# Altium schematic sheet WirelessLamp.SchDoc(WirelessLamp)
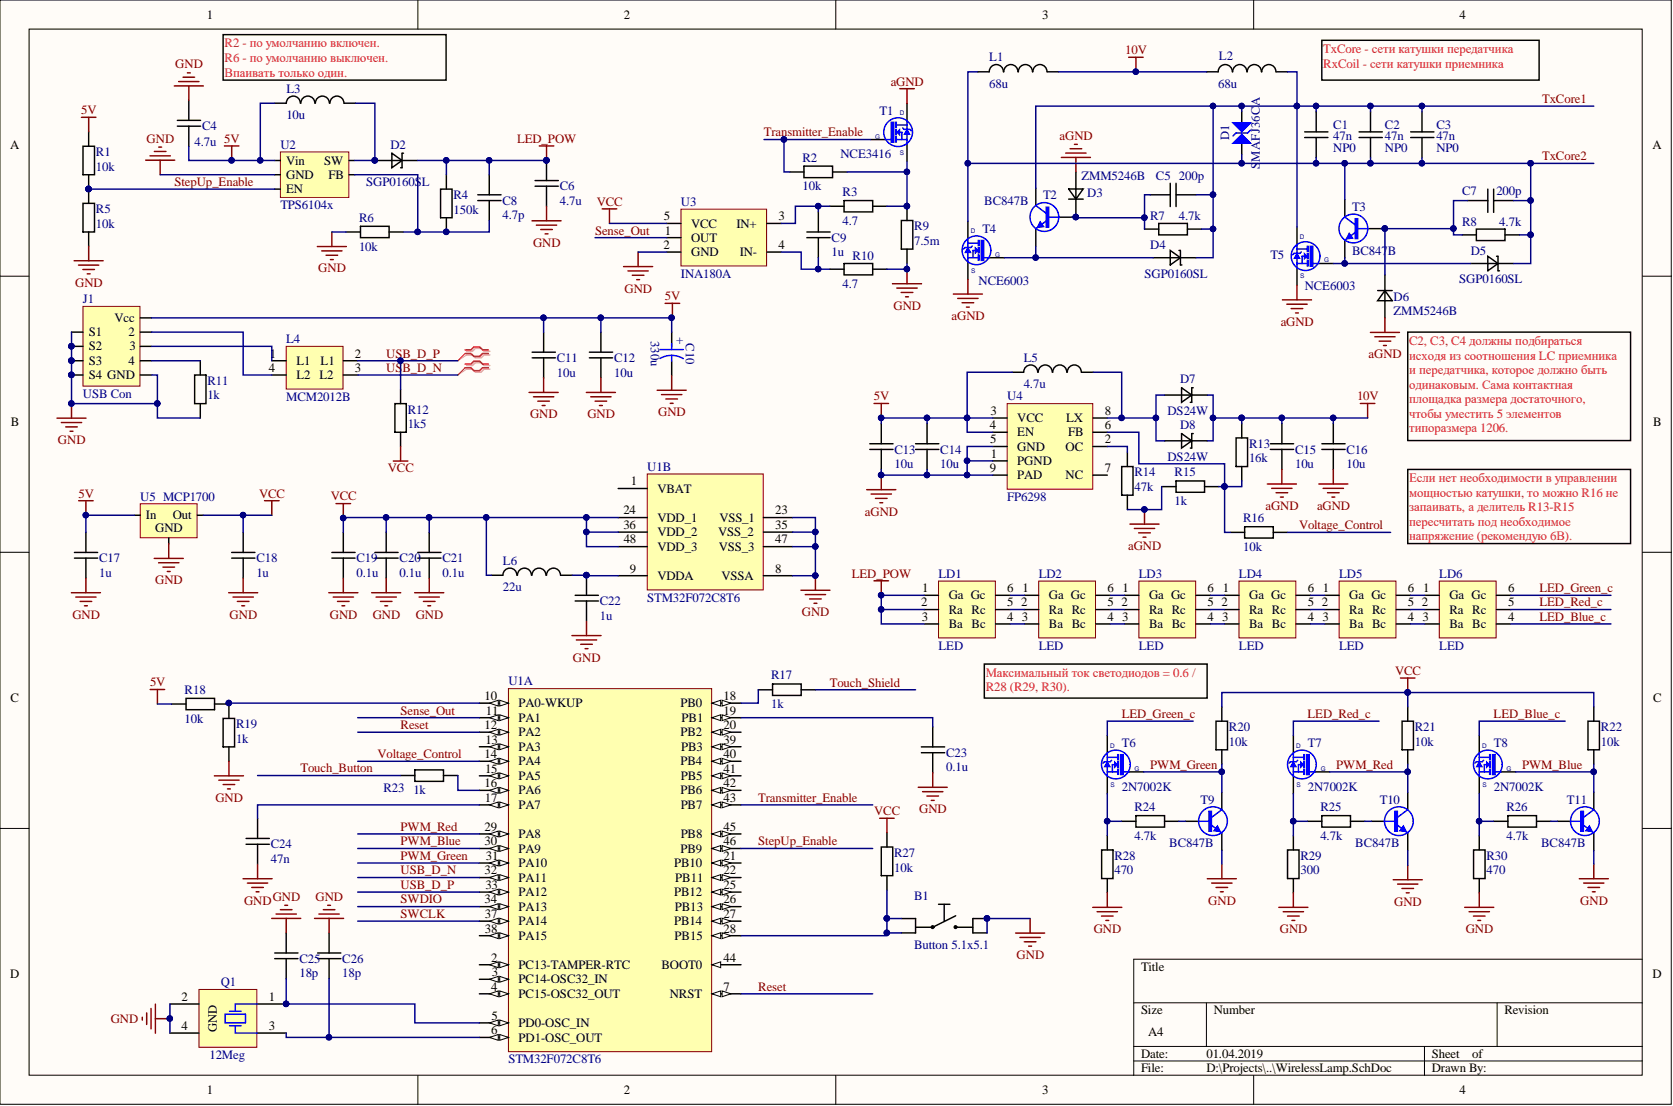
[diagram p0: WirelessLamp.SchDoc]

## Parts
B1  Button 5.1x5.1  [TS-1187 combo]
C1  47n  Name: NP0  [CAPC3225L]
C2  47n  Name: NP0  [CAPC3225L]
C3  47n  Name: NP0  [CAPC3225L]
C4  4.7u  [CAPC3216L]
C5  200p  [CAPC2012L]
C6  4.7u  [CAPC3216L]
C7  200p  [CAPC2012L]
C8  4.7p  [CAPC1608L]
C9  1u  [CAPC1608L]
C10  330u  [CAPPR250-680X1080]
C11  10u  [CAPC3216L]
C12  10u  [CAPC3216L]
C13  10u  [CAPC3216L]
C14  10u  [CAPC3216L]
C15  10u  [CAPC3225L]
C16  10u  [CAPC3225L]
C17  1u  [CAPC2012L]
C18  1u  [CAPC2012L]
C19  0.1u  [CAPC1608L]
C20  0.1u  [CAPC1608L]
C21  0.1u  [CAPC1608L]
C22  1u  [CAPC1608L]
C23  0.1u  [CAPC2012L]
C24  47n  [CAPC3216L]
C25  18p  [CAPC1608L]
C26  18p  [CAPC1608L]
D1  SMAFJ36CA  [DIOM5226X262N]
D2  SGP0160SL  [SOD-323F]
D3  ZMM5246B  [MELF-D3512-1405]
D4  SGP0160SL  [SOD-323F]
D5  SGP0160SL  [SOD-323F]
D6  ZMM5246B  [MELF-D3512-1405]
D7  DS24W  [SOD123]
D8  DS24W  [SOD123]
J1  USB Con  [ZX62D-B-5PA8]
L1  68u  [Ind 8x8]
L2  68u  [Ind 8x8]
L3  10u  [INDC3225L]
L4  MCM2012B  [MCM2012B]
L5  4.7u  [Ind 8x8]
L6  22u  [INDC2012L]
LD1  LED  [LED5060]
LD2  LED  [LED5060]
LD3  LED  [LED5060]
LD4  LED  [LED5060]
LD5  LED  [LED5060]
LD6  LED  [LED5060]
Q1  12Meg  [XTAL_ABRACON_ABM8-166]
R1  10k  [RESC1608L]
R2  10k  [RESC1608L]
R3  4.7  [RESC1608L]
R4  150k  [RESC1608L]
R5  10k  [RESC1608L]
R6  10k  [RESC1608L]
R7  4.7k  [RESC2012L]
R8  4.7k  [RESC2012L]
R9  7.5m  [RESC2012M]
R10  4.7  [RESC1608L]
R11  1k  [RESC1608L]
R12  1k5  [RESC1608L]
R13  16k  [RESC1608L]
R14  47k  [RESC1608L]
R15  1k  [RESC1608L]
R16  10k  [RESC1608L]
R17  1k  [RESC1608L]
R18  10k  [RESC1608L]
R19  1k  [RESC1608L]
R20  10k  [RESC1608L]
R21  10k  [RESC1608L]
R22  10k  [RESC1608L]
R23  1k  [RESC1608L]
R24  4.7k  [RESC1608L]
R25  4.7k  [RESC1608L]
R26  4.7k  [RESC1608L]
R27  10k  [RESC1608L]
R28  470  [RESC2012L]
R29  300  [RESC2012L]
R30  470  [RESC2012L]
T1  NCE3416  [SOT95P237X112-3N]
T2  BC847B  [SOT95P230X110-3N]
T3  BC847B  [SOT95P230X110-3N]
T4  NCE6003  [SOT95P237X112-3N]
T5  NCE6003  [SOT95P237X112-3N]
T6  2N7002K  [SOT95P237X112-3N]
T7  2N7002K  [SOT95P237X112-3N]
T8  2N7002K  [SOT95P237X112-3N]
T9  BC847B  [SOT95P230X110-3N]
T10  BC847B  [SOT95P230X110-3N]
T11  BC847B  [SOT95P230X110-3N]
U1  STM32F072C8T6  [STM-LQFP48_N]  (parts A,B)
U2  TPS6104x  [SOT23-5AL]
U3  INA180A  [SOT23-5AN]
U4  FP6298  [SOIC127P600-8DL]
U5  MCP1700  [SOT23-3L]

## Nets
5V: C4.1 C10.1 C11.2 C12.2 C13.2 C14.2 C17.2 J1.1(Vcc) L3.1 L5.1 R1.2 R18.2 U2.5(Vin) U4.3(VCC) U4.4(EN) U5.3
10V: C15.2 C16.2 D7.2 D8.2 L1.2 L2.1 R13.2
aGND: C13.1 C14.1 C15.1 C16.1 D3.1 D6.1 R14.1 R15.1 T1.3 T4.2 T5.2 U4.1(PGND) U4.5(GND) U4.9(PAD)
GND: B1.3 B1.4 C4.2 C6.1 C10.2 C11.1 C12.1 C17.1 C18.1 C19.1 C20.1 C21.1 C22.1 C23.1 C24.1 C25.2 C26.2 J1.5(GND) J1.S1 J1.S2 J1.S3 J1.S4 Q1.2 Q1.4 R5.1 R6.1 R9.1 R10.2 R11.2 R19.1 R28.2 R29.1 R30.1 T9.2 T10.2 T11.2 U1.8(VSSA) U1.23(VSS_1) U1.35(VSS_2) U1.47(VSS_3) U2.2(GND) U3.2(GND) U5.1
LED_POW: C6.2 C8.2 D2.2 LD1.1(Ga) LD1.2(Ra) LD1.3(Ba) R4.2
VCC: C18.2 C19.2 C20.2 C21.2 L6.1 R12.1 R20.2 R21.2 R22.2 R27.2 U1.24(VDD_1) U1.36(VDD_2) U1.48(VDD_3) U3.5(VCC) U5.2
LED_Blue_c: LD6.4(Bc) T8.3
LED_Green_c: LD6.6(Gc) T6.3
LED_Red_c: LD6.5(Rc) T7.3
PWM_Blue: R22.1 T8.1 T11.3 U1.30(PA9)
PWM_Green: R20.1 T6.1 T9.3 U1.31(PA10)
PWM_Red: R21.1 T7.1 T10.3 U1.29(PA8)
Reset: U1.7(NRST) U1.12(PA2)
Sense_Out: U1.11(PA1) U3.1(OUT)
StepUp_Enable: R1.1 R5.2 U1.46(PB9) U2.4(EN)
SWCLK: U1.37(PA14)
SWDIO: U1.34(PA13)
Touch_Button: R23.1
Touch_Shield: R17.2
Transmitter_Enable: R2.1 T1.1 U1.43(PB7)
TxCore1: C1.2 C2.2 C3.2 C5.1 D1.1 D4.2 L2.2 R7.1 T2.3 T5.3
TxCore2: C1.1 C2.1 C3.1 C7.1 D1.2 D5.2 L1.1 R8.1 T3.3 T4.3
USB_D_N: L4.3(L2) U1.32(PA11)
USB_D_P: L4.2(L1) R12.2 U1.33(PA12)
Voltage_Control: R16.2 U1.14(PA4)
NetB1_1: B1.1 B1.2 R27.1 U1.28(PB15)
NetC5_2: C5.2 D3.2 R7.2 T2.1
NetC7_2: C7.2 D6.2 R8.2 T3.1
NetC8_1: C8.1 R4.1 R6.2 U2.3(FB)
NetC9_1: C9.1 R10.1 U3.4(IN-)
NetC9_2: C9.2 R3.1 U3.3(IN+)
NetC22_2: C22.2 L6.2 U1.9(VDDA)
NetC23_2: C23.2 U1.19(PB1)
NetC24_2: C24.2 U1.17(PA7)
NetC25_1: C25.1 Q1.1 U1.5(PD0-OSC_IN)
NetC26_1: C26.1 Q1.3 U1.6(PD1-OSC_OUT)
NetD2_1: D2.1 L3.2 U2.1(SW)
NetD4_1: D4.1 T2.2 T4.1
NetD5_1: D5.1 T3.2 T5.1
NetD7_1: D7.1 D8.1 L5.2 U4.8(LX)
NetJ1_2: J1.2 L4.4(L2)
NetJ1_3: J1.3 L4.1(L1)
NetJ1_4: J1.4 R11.1
NetLD1_4: LD1.4(Bc) LD2.3(Ba)
NetLD1_5: LD1.5(Rc) LD2.2(Ra)
NetLD1_6: LD1.6(Gc) LD2.1(Ga)
NetLD2_4: LD2.4(Bc) LD3.3(Ba)
NetLD2_5: LD2.5(Rc) LD3.2(Ra)
NetLD2_6: LD2.6(Gc) LD3.1(Ga)
NetLD3_4: LD3.4(Bc) LD4.3(Ba)
NetLD3_5: LD3.5(Rc) LD4.2(Ra)
NetLD3_6: LD3.6(Gc) LD4.1(Ga)
NetLD4_4: LD4.4(Bc) LD5.3(Ba)
NetLD4_5: LD4.5(Rc) LD5.2(Ra)
NetLD4_6: LD4.6(Gc) LD5.1(Ga)
NetLD5_4: LD5.4(Bc) LD6.3(Ba)
NetLD5_5: LD5.5(Rc) LD6.2(Ra)
NetLD5_6: LD5.6(Gc) LD6.1(Ga)
NetR2_2: R2.2 R3.2 R9.2 T1.2
NetR13_1: R13.1 R15.2 R16.1 U4.6(FB)
NetR14_2: R14.2 U4.2(OC)
NetR17_1: R17.1 U1.18(PB0)
NetR18_1: R18.1 R19.2 U1.10(PA0-WKUP)
NetR23_2: R23.2 U1.16(PA6)
NetR24_1: R24.1 R28.1 T6.2
NetR24_2: R24.2 T9.1
NetR25_1: R25.1 R29.2 T7.2
NetR25_2: R25.2 T10.1
NetR26_1: R26.1 R30.2 T8.2
NetR26_2: R26.2 T11.1

Not connected: U1 1(VBAT) 2(PC13-TAMPER-RTC) 3(PC14-OSC32_IN) 4(PC15-OSC32_OUT) 13(PA3) 15(PA5) 20(PB2) 21(PB10) 22(PB11) 25(PB12) 26(PB13) 27(PB14) 38(PA15) 39(PB3) 40(PB4) 41(PB5) 42(PB6) 44(BOOT0) 45(PB8); U4 7(NC)

## Library data of the parts
B1 = Button 5.1x5.1: Part: TS-1187A-B-A-B; Link: https://lcsc.com/product-detail/Tactile-Switches_XKB-Enterprise-TS-1187-B-A-A_C318884.html; LCSC Part: C318884; Component Kind: Standard; Library Name: WirelessLamp_lib.IntLib
C1-C3 = Capacitor: Part: GRM31M5C1H473JA01L; Voltage: 50V; Value: 47n; Link: https://lcsc.com/product-detail/Multilayer-Ceramic-Capacitors-MLCC-SMD-SMT_muRata_GRM31M5C1H473JA01L_47nF-473-10-50V_C21812.html; LCSC Part: C21812; Component Kind: Standard; Case: 1206; Library Name: BMFT_lib.IntLib
C4 C8 C9 C11 C12 C17-C26 = Capacitor: Component Kind: Standard; Library Name: BMFT_lib.IntLib
  C4: Value: 4.7u; Case: 1206
  C8: Value: 4.7p; Case: 0603
  C9 C22: Value: 1u; Case: 0603
  C11 C12: Value: 10u; Case: 1206
  C17 C18: Value: 1u
  C19-C21: Value: 0.1u; Case: 0603
  C23: Value: 0.1u; Case: 0805
  C24: Value: 47n; Case: 1206
  C25 C26: Value: 18p; Case: 0603
C5-C7 C15 C16 = Capacitor: Voltage: 50V; Component Kind: Standard; Library Name: BMFT_lib.IntLib
  C5 C7: Value: 200p; Case: 0805
  C6: Value: 4.7u; Case: 1206
  C15 C16: Value: 10u; Case: 1210
C10 = CAER_100UF_16V_20%_CHEMI-CON_APSA160ELL101MFA5G: Polarised capacitor; Part: 6R3AREP331M06X5E20; Voltage: 6.3V; Database Table Name: Capacitors THD; Value: 330u; Link: https://lcsc.com/product-detail/Solid-Polymer-Electrolytic-Capacitor_330uF-6-3V_C176297.html; Library Name: Capacitors.DbLib; LCSC Part: C176297; Component Kind: Standard; Case: LS2.5 D6.3 x H10.5; Author: CERN DEM JLC
C13 C14 = Capacitor: Voltage: 16V; Value: 10u; Component Kind: Standard; Case: 1206; Library Name: BMFT_lib.IntLib
D1 = SMAJ24CA: Transient Voltage Suppressor Diode (Bi-Directional); Database Table Name: Diodes; Part: SMAFJ36CA; Name: SMAFJ36CA; Link: https://lcsc.com/product-detail/TVS_SMAFJ36CA_C169459.html; Library Name: ICs And Semiconductors.DbLib; LCSC Part: C169459; Component Kind: Standard; Case: DO-214AC; Author: CERN DEM JLC
D2 D4 D5 D7 D8 = Schotky: Component Kind: Standard; Library Name: BMFT_lib.IntLib
  D2 D5: Part: SGP0160SL; Name: SGP0160SL; Link: https://lcsc.com/product-detail/Schottky-Barrier-Diodes-SBD_SGP0160SL_C110413.html; LCSC Part: C110413; Case: SOD-323F
  D4: Part: SGP0160SL; Name: SGP0160SL; Limk: https://lcsc.com/product-detail/Schottky-Barrier-Diodes-SBD_SGP0160SL_C110413.html; LCSC Part: C110413; Case: SOD-323F
  D7 D8: Part: DS24W; Name: DS24W; Link: https://lcsc.com/product-detail/Schottky-Barrier-Diodes-SBD_DS24W_C108810.html; LCSC Part: C108810; Case: SOD-123F
D3 D6 = Diode_MELF: Part: ZMM5246B; Name: ZMM5246B; Link: https://lcsc.com/product-detail/Zener-Diodes_ZMM5246B_C118699.html; LCSC Part: C118699; Component Kind: Standard; Case: LL-34; Library Name: BMFT_lib.IntLib
J1 = USB: Datasheet: https://media.digikey.com/pdf/Data%20Sheets/Hirose%20PDFs/ZX62D-AB-5P8%20RoHS.pdf; Component Kind: Standard; Library Name: BMFT_lib.IntLib
L1 L2 = Inductor: Part: SMNR8040-680MT; Value: 68u; Name: SMNR8040-680MT; Link: https://lcsc.com/product-detail/Inductors-SMD_ShunXiang-Connaught-Elec-SMNR8040-680MT_C266439.html; LCSC Part: C266439; Component Kind: Standard; Case: 8040; Library Name: WirelessLamp_lib.IntLib
L3 = Inductor: Part: MRSC252A10-100M-N; Name: Chilisin Elec MRSC252A10-100M-N; Value: 10u; Link: https://lcsc.com/product-detail/Inductors-SMD_Chilisin-Elec-MRSC252A10-100M-N_C329581.html; LCSC Part: C329581; Component Kind: Standard; Case: SMD,2.5x2.0x1.0mm; Library Name: BMFT_lib.IntLib
L4 = MCM2012B: Part: MCM2012B900GBE; Name: MCM2012B; Link: https://lcsc.com/product-detail/EMI-RFI-Filters-LC-RC-Networks_INPAQ-MCM2012B900GBE_C315725.html; LCSC Part: C315725; Component Kind: Standard; Library Name: WirelessLamp_lib.IntLib
L5 = Inductor: Part: SMNR8040-4R7MT; Value: 4.7u; Name: SMNR8040-4R7MT; Link: https://lcsc.com/product-detail/Inductors-SMD_ShunXiang-Connaught-Elec-SMNR8040-4R7MT_C266436.html; LCSC Part: C266436; Component Kind: Standard; Case: 8040; Library Name: WirelessLamp_lib.IntLib
L6 = Inductor: Value: 22u; Component Kind: Standard; Case: 0805; Library Name: BMFT_lib.IntLib
LD1-LD6 = RGB LED 5060: Part: HL-AF-5060H271BU46FU79GC-S1-THL; Name: LED; Link: https://lcsc.com/product-detail/Light-Emitting-Diodes-LED_Hongli-Zhihui-HONGLITRONIC-HL-AF-5060H271BU46FU79GC-S1-THL_C219409.html; LCSC Part: C219409; Component Kind: Standard; Library Name: WirelessLamp_lib.IntLib
Q1 = XTAL_114.285MHZ_ABRACON_ABM8-166-114.285MHZ: Crystal 4 pins 1=XTAL Control 2=GND3=XTAL 4=GND; Part: 7U12000E12UCG; Database Table Name: Crystals & Oscillators; Value: 12Meg; Link: https://lcsc.com/product-detail/SMD-Crystals_SHENZHEN-CRYSTAL-TECH-7U12000E12UCG_C251605.html; Library Name: ICs And Semiconductors.DbLib; LCSC Part: C251605; Component Kind: Standard; Author: CERN DEM MR
R1-R30 = Resistor: Component Kind: Standard; Library Name: BMFT_lib.IntLib
  R1 R2 R5 R6 R16 R18 R20-R22 R27: Value: 10k; Case: 0603
  R3 R10: Value: 4.7; Case: 0603
  R4: Value: 150k; Case: 0603
  R7 R8: Value: 4.7k; Case: 0805
  R9: Value: 7.5m; LCSC Part: C156368; Case: 0805
  R11: Value: 1k
  R12: Value: 1k5
  R13: Value: 16k; Case: 0603
  R14: Value: 47k; Case: 0603
  R15 R17 R19 R23: Value: 1k; Case: 0603
  R24-R26: Value: 4.7k; Case: 0603
  R28 R30: Value: 470; Case: 0805
  R29: Value: 300; Case: 0805
T1 T4-T8 = IRLML2803PBF: N-CHANNEL MOSFET WITH ZENER DIODE; Database Table Name: Transistors; Library Name: ICs And Semiconductors.DbLib; Component Kind: Standard; Case: SOT23-3; Author: CERN DEM JMW
  T1: Part: NCE3416; Name: NCE3416; Link: https://lcsc.com/product-detail/MOSFET_Wuxi-NCE-Power-Semiconductor-NCE3416_C113491.html; LCSC Part: C113491
  T4 T5: Part: NCE6003; Name: NCE6003; Link: https://lcsc.com/product-detail/MOSFET_Wuxi-NCE-Power-Semiconductor-NCE6003_C112351.html; LCSC Part: C112351
  T6-T8: Part: 2N7002K; Name: 2N7002K; Link: https://lcsc.com/product-detail/MOSFET_Changjiang-Electronics-Tech-CJ-2N7002K_C38161.html; LCSC Part: C38161
T2 T3 T9-T11 = BC847B: NPN Transistor; Database Table Name: Transistors; Name: BC847B; Library Name: ICs And Semiconductors.DbLib; Component Kind: Standard; Case: SOT23-3; Author: CERN DEM JLC
U1 = STM32F103C8T6: ARM Cortex-M3 32-bit MCU, 64 KB Flash, 20 KB Internal RAM, 37 I/Os, 48-pin LQFP, -40 to 85 degC, Tray; Part: STM32F072C8T6; Name: STM32F072C8T6; Library Name: STMicroelectronics STM32 F1.IntLib; LCSC Part: C80488; Component Kind: Standard; Case: LQFP48
U2 = TPS6104x: Part: TPS61041DBVR; Name: TPS6104x; Link: https://lcsc.com/product-detail/DC-DC-Converters_TI_TPS61041DBVR_TPS61041DBVR_C9846.html; LCSC Part: C9846; Component Kind: Standard; Library Name: BMFT_lib.IntLib
U3 = INA180A: Part: INA180A4IDBVR; Name: INA180A; Link: https://lcsc.com/product-detail/PMIC-Current-Power-Monitors-Regulators_TI_INA180A4IDBVR_INA180A4IDBVR_C188337.html; LCSC Part: C188337; Component Kind: Standard; Library Name: WirelessLamp_lib.IntLib
U4 = FP6298: Part: FP6298XR-G1; Name: FP6298; Link: https://lcsc.com/product-detail/DC-DC-Converters_FP6298XR-G1_C88319.html; LCSC Part: C88319; Component Kind: Standard; Library Name: WirelessLamp_lib.IntLib
U5 = MCP1700: Part: RS3219-3.3YF3; Value: 3.3V; Name: MCP1700; Link: https://lcsc.com/product-detail/Low-Dropout-Regulators-LDO_Jiangsu-RUNIC-Tech-RS3219-3-3YF3_C255487.html; LCSC Part: C255487; Component Kind: Standard; Library Name: BMFT_lib.IntLib
